# Altium schematic sheet Pack_monitoring.SchDoc(Pack_monitoring)
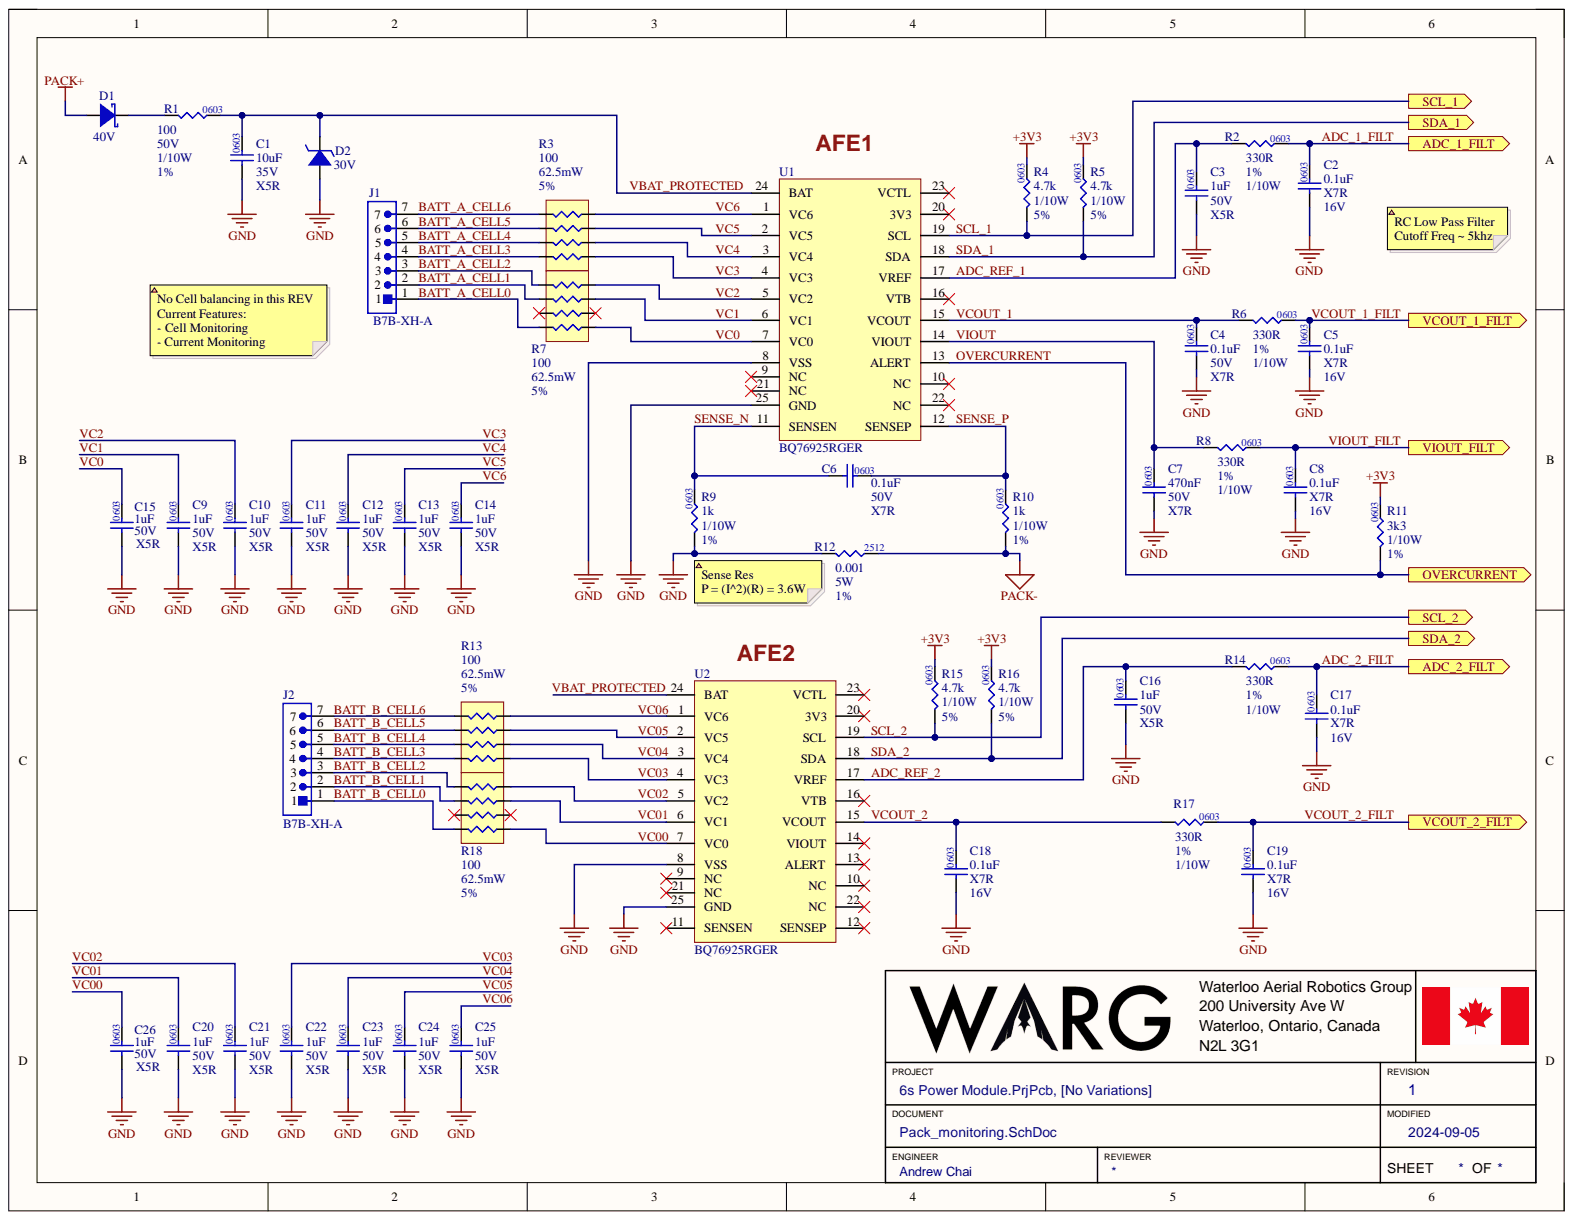
[diagram p0: Pack_monitoring.SchDoc]

## Parts
C1  value 10uF  Case/Package: 0603  Rated DC Voltage (URdc): 35V  Temperature Characteristics Code: X5R  [.CAP_0603-Footprint-1]  (hidden comment: GRM188R6YA106MA73J)
C2  value 0.1uF  Capactitance: 0.1uF  Package: 0603  Temperature Coefficient: X7R  Voltage: 16V  [.CAP_0603-Footprint-1]  (hidden comment: GCM188R71C104KA37D)
C3  value 1uF  Capacitance: 1uF  Case/Package: 0603  Dielectric: X5R  Rated DC Voltage (URdc): 50V  [.CAP_0603-Footprint-1]  (hidden comment: GRT188R61H105KE13D)
C4  Capacitance: 0.1uF  Case Code (Imperial): 0603  Dielectric: X7R  Voltage: 50V  [CAP0603]  (hidden comment: GCM188R71H104KA57D)
C5  value 0.1uF  Capactitance: 0.1uF  Package: 0603  Temperature Coefficient: X7R  Voltage: 16V  [.CAP_0603-Footprint-1]  (hidden comment: GCM188R71C104KA37D)
C6  Capacitance: 0.1uF  Case Code (Imperial): 0603  Dielectric: X7R  Voltage: 50V  [CAP0603]  (hidden comment: GCM188R71H104KA57D)
C7  Capacitance: 470nF  Case Code (Imperial): 0603  Dielectric: X7R  Voltage: 50V  [FP-0603-L_1_6_0_1-W_0_8_0-IPC_A]  (hidden comment: UMK107B7474KA-TR)
C8  value 0.1uF  Capactitance: 0.1uF  Package: 0603  Temperature Coefficient: X7R  Voltage: 16V  [.CAP_0603-Footprint-1]  (hidden comment: GCM188R71C104KA37D)
C9  value 1uF  Capacitance: 1uF  Case/Package: 0603  Dielectric: X5R  Rated DC Voltage (URdc): 50V  [.CAP_0603-Footprint-1]  (hidden comment: GRT188R61H105KE13D)
C10  value 1uF  Capacitance: 1uF  Case/Package: 0603  Dielectric: X5R  Rated DC Voltage (URdc): 50V  [.CAP_0603-Footprint-1]  (hidden comment: GRT188R61H105KE13D)
C11  value 1uF  Capacitance: 1uF  Case/Package: 0603  Dielectric: X5R  Rated DC Voltage (URdc): 50V  [.CAP_0603-Footprint-1]  (hidden comment: GRT188R61H105KE13D)
C12  value 1uF  Capacitance: 1uF  Case/Package: 0603  Dielectric: X5R  Rated DC Voltage (URdc): 50V  [.CAP_0603-Footprint-1]  (hidden comment: GRT188R61H105KE13D)
C13  value 1uF  Capacitance: 1uF  Case/Package: 0603  Dielectric: X5R  Rated DC Voltage (URdc): 50V  [.CAP_0603-Footprint-1]  (hidden comment: GRT188R61H105KE13D)
C14  value 1uF  Capacitance: 1uF  Case/Package: 0603  Dielectric: X5R  Rated DC Voltage (URdc): 50V  [.CAP_0603-Footprint-1]  (hidden comment: GRT188R61H105KE13D)
C15  value 1uF  Capacitance: 1uF  Case/Package: 0603  Dielectric: X5R  Rated DC Voltage (URdc): 50V  [.CAP_0603-Footprint-1]  (hidden comment: GRT188R61H105KE13D)
C16  value 1uF  Capacitance: 1uF  Case/Package: 0603  Dielectric: X5R  Rated DC Voltage (URdc): 50V  [.CAP_0603-Footprint-1]  (hidden comment: GRT188R61H105KE13D)
C17  value 0.1uF  Capactitance: 0.1uF  Package: 0603  Temperature Coefficient: X7R  Voltage: 16V  [.CAP_0603-Footprint-1]  (hidden comment: GCM188R71C104KA37D)
C18  value 0.1uF  Capactitance: 0.1uF  Package: 0603  Temperature Coefficient: X7R  Voltage: 16V  [.CAP_0603-Footprint-1]  (hidden comment: GCM188R71C104KA37D)
C19  value 0.1uF  Capactitance: 0.1uF  Package: 0603  Temperature Coefficient: X7R  Voltage: 16V  [.CAP_0603-Footprint-1]  (hidden comment: GCM188R71C104KA37D)
C20  value 1uF  Capacitance: 1uF  Case/Package: 0603  Dielectric: X5R  Rated DC Voltage (URdc): 50V  [.CAP_0603-Footprint-1]  (hidden comment: GRT188R61H105KE13D)
C21  value 1uF  Capacitance: 1uF  Case/Package: 0603  Dielectric: X5R  Rated DC Voltage (URdc): 50V  [.CAP_0603-Footprint-1]  (hidden comment: GRT188R61H105KE13D)
C22  value 1uF  Capacitance: 1uF  Case/Package: 0603  Dielectric: X5R  Rated DC Voltage (URdc): 50V  [.CAP_0603-Footprint-1]  (hidden comment: GRT188R61H105KE13D)
C23  value 1uF  Capacitance: 1uF  Case/Package: 0603  Dielectric: X5R  Rated DC Voltage (URdc): 50V  [.CAP_0603-Footprint-1]  (hidden comment: GRT188R61H105KE13D)
C24  value 1uF  Capacitance: 1uF  Case/Package: 0603  Dielectric: X5R  Rated DC Voltage (URdc): 50V  [.CAP_0603-Footprint-1]  (hidden comment: GRT188R61H105KE13D)
C25  value 1uF  Capacitance: 1uF  Case/Package: 0603  Dielectric: X5R  Rated DC Voltage (URdc): 50V  [.CAP_0603-Footprint-1]  (hidden comment: GRT188R61H105KE13D)
C26  value 1uF  Capacitance: 1uF  Case/Package: 0603  Dielectric: X5R  Rated DC Voltage (URdc): 50V  [.CAP_0603-Footprint-1]  (hidden comment: GRT188R61H105KE13D)
D1  Rep Pk Reverse Voltage-Max: 40V  [Copy of .SCHOTTKY-Footprint-1]  (hidden comment: MBR0540T1G)
D2  Reference Voltage-Nom: 30V  [BZT52C30-13-Footprint-1]  (hidden comment: BZT52C30-13)
J1  B7B-XH-A  [B7B-XH-A]
J2  B7B-XH-A  [B7B-XH-A]
R1  Case Code (Imperial): 0603  Voltage Rating: 50V  [.RES_0603-Footprint-1]  (hidden comment: RC0603FR-07100RL)
R2  value 330R  Case/Package: 0603  Tolerance: 1%  [.RES_0603-Footprint-1]  (hidden comment: AF0603FR-07330RL)
R3  value 100  Power: 62.5mW  Tolerance: 5%  [.RES_0603-Footprint-1]  (hidden comment: YC124JR-07100RL)
R4  value 4.7k  Case/Package: 0603  Power Rating: 1/10W  Resistance: 4.7k  Resistance Tolerance: 5%  [.RES_0603-Footprint-1]  (hidden comment: RC0603JR-074K7L)
R5  value 4.7k  Case/Package: 0603  Power Rating: 1/10W  Resistance: 4.7k  Resistance Tolerance: 5%  [.RES_0603-Footprint-1]  (hidden comment: RC0603JR-074K7L)
R6  value 330R  Case/Package: 0603  Tolerance: 1%  [.RES_0603-Footprint-1]  (hidden comment: AF0603FR-07330RL)
R7  value 100  [.RES_0603-Footprint-1]  (hidden comment: YC124JR-07100RL)
R8  value 330R  Case/Package: 0603  Tolerance: 1%  [.RES_0603-Footprint-1]  (hidden comment: AF0603FR-07330RL)
R9  value 1k  Case/Package: 0603  Power: 1/10W  Tolerance: 1%  [.RES_0603-Footprint-1]  (hidden comment: RC0603FR-071KL)
R10  value 1k  Case/Package: 0603  Power: 1/10W  Tolerance: 1%  [.RES_0603-Footprint-1]  (hidden comment: RC0603FR-071KL)
R11  value 3k3  Case/Package: 0603  Power: 1/10W  Tolerance: 1%  [.RES_0603-Footprint-1]  (hidden comment: RC0603FR-103K3L)
R12  value 0.001  Case/Package: 2512  Power: 5W  Resistance: 0.001  [.RES_0603-Footprint-1]  (hidden comment: CSS2H-2512R-1L00F)
R13  value 100  Power: 62.5mW  Tolerance: 5%  [.RES_0603-Footprint-1]  (hidden comment: YC124JR-07100RL)
R14  value 330R  Case/Package: 0603  Tolerance: 1%  [.RES_0603-Footprint-1]  (hidden comment: AF0603FR-07330RL)
R15  value 4.7k  Case/Package: 0603  Power Rating: 1/10W  Resistance: 4.7k  Resistance Tolerance: 5%  [.RES_0603-Footprint-1]  (hidden comment: RC0603JR-074K7L)
R16  value 4.7k  Case/Package: 0603  Power Rating: 1/10W  Resistance: 4.7k  Resistance Tolerance: 5%  [.RES_0603-Footprint-1]  (hidden comment: RC0603JR-074K7L)
R17  value 330R  Case/Package: 0603  Tolerance: 1%  [.RES_0603-Footprint-1]  (hidden comment: AF0603FR-07330RL)
R18  value 100  [.RES_0603-Footprint-1]  (hidden comment: YC124JR-07100RL)
U1  BQ76925RGER  [QFN50P400X400X100_HS-25N]
U2  BQ76925RGER  [QFN50P400X400X100_HS-25N]

## Ports
ADC_1_FILT, ADC_2_FILT, OVERCURRENT, SCL_1, SCL_2, SDA_1, SDA_2, VCOUT_1_FILT, VCOUT_2_FILT, VIOUT_FILT

## Nets
+3V3: R4.2 R5.2 R11.2 R15.2 R16.2
GND: C1.1 C2.1 C3.1 C4.1 C5.1 C7.1 C8.1 C9.1 C10.1 C11.1 C12.1 C13.1 C14.1 C15.1 C16.1 C17.1 C18.1 C19.1 C20.1 C21.1 C22.1 C23.1 C24.1 C25.1 C26.1 D2.1 R9.1 R12.1 U1.8(VSS) U1.25(GND) U2.8(VSS) U2.25(GND)
PACK+: D1.1
PACK-: R10.1 R12.2
ADC_1_FILT: C2.2 R2.2 ; port ADC_1_FILT
ADC_2_FILT: C17.2 R14.2 ; port ADC_2_FILT
ADC_REF_1: C3.2 R2.1 U1.17(VREF)
ADC_REF_2: C16.2 R14.1 U2.17(VREF)
BATT_A_CELL0: J1.1 R7.7
BATT_A_CELL1: J1.2 R7.3
BATT_A_CELL2: J1.3 R7.1
BATT_A_CELL3: J1.4 R3.7
BATT_A_CELL4: J1.5 R3.5
BATT_A_CELL5: J1.6 R3.3
BATT_A_CELL6: J1.7 R3.1
BATT_B_CELL0: J2.1 R18.7
BATT_B_CELL1: J2.2 R18.3
BATT_B_CELL2: J2.3 R18.1
BATT_B_CELL3: J2.4 R13.7
BATT_B_CELL4: J2.5 R13.5
BATT_B_CELL5: J2.6 R13.3
BATT_B_CELL6: J2.7 R13.1
OVERCURRENT: R11.1 U1.13(ALERT) ; port OVERCURRENT
SCL_1: R4.1 U1.19(SCL) ; port SCL_1
SCL_2: R15.1 U2.19(SCL) ; port SCL_2
SDA_1: R5.1 U1.18(SDA) ; port SDA_1
SDA_2: R16.1 U2.18(SDA) ; port SDA_2
SENSE_N: C6.1 R9.2 U1.11(SENSEN)
SENSE_P: C6.2 R10.2 U1.12(SENSEP)
VBAT_PROTECTED: C1.2 D2.2 R1.2 U1.24(BAT) U2.24(BAT)
VC0: C15.2 C26.2 R7.8 R18.8 U1.7(VC0) U2.7(VC0)  [also labelled VC00]
VC1: C9.2 C20.2 R7.4 R18.4 U1.6(VC1) U2.6(VC1)  [also labelled VC01]
VC2: C10.2 C21.2 R7.2 R18.2 U1.5(VC2) U2.5(VC2)  [also labelled VC02]
VC3: C11.2 C22.2 R3.8 R13.8 U1.4(VC3) U2.4(VC3)  [also labelled VC03]
VC4: C12.2 C23.2 R3.6 R13.6 U1.3(VC4) U2.3(VC4)  [also labelled VC04]
VC5: C13.2 C24.2 R3.4 R13.4 U1.2(VC5) U2.2(VC5)  [also labelled VC05]
VC6: C14.2 C25.2 R3.2 R13.2 U1.1(VC6) U2.1(VC6)  [also labelled VC06]
VCOUT_1: C4.2 R6.1 U1.15(VCOUT)
VCOUT_1_FILT: C5.2 R6.2 ; port VCOUT_1_FILT
VCOUT_2: C18.2 R17.1 U2.15(VCOUT)
VCOUT_2_FILT: C19.2 R17.2 ; port VCOUT_2_FILT
VIOUT: C7.2 R8.1 U1.14(VIOUT)
VIOUT_FILT: C8.2 R8.2 ; port VIOUT_FILT
NetD1_2: D1.2 R1.1

Not connected: R7 5 6; R18 5 6; U1 9(NC) 10(NC) 16(VTB) 20(3V3) 21(NC) 22(NC) 23(VCTL); U2 9(NC) 10(NC) 11(SENSEN) 12(SENSEP) 13(ALERT) 14(VIOUT) 16(VTB) 20(3V3) 21(NC) 22(NC) 23(VCTL)

## Library data of the parts
C1 = CMP-001-00087-1: Ceramic Capacitor, Multilayer, Ceramic, 35V, 20% +Tol, 20% -Tol, X5R, 15% TC, 10uF, Surface Mount, 0603; Multilayer: Yes; Capacitor Type: Ceramic; Component Kind: Standard; Dielectric Material: Ceramic; JESD-609 Code: e3; Max Operating Temperature: 85°C; Min Operating Temperature: -55°C; Mounting Technology: Surface Mount; Package Shape: Rectangular; Packaging: Tape and Reel; Pins: 2; Reach SVHC Compliant: Yes; RoHS Compliant: Yes; Terminal Finish: Nickel; Termination: Wraparound; Tolerance  (fill in as +-): 20%; Tolerance (fill in as +-): 20%; Library Name: WARG
C2 C5 C8 C17-C19 = CMP-001-00020-5: CAP CER 0.1uF 16V X7R 0603; Component Kind: Standard; Library Name: WARG
C3 C9-C16 C20-C26 = CMP-00096-3: CAP CER 1UF 50V X5R 0603; Capacitor Type: Ceramic; Tolerance: 10%; Component Kind: Standard; Mount: Surface Mount; Temperature Characteristics Code: X5R; Voltage Rating: 50V; Library Name: WARG
C4 C6 = CMP-00047-2: CAP CER 0.1uF 50V X7R 0603; Tolerance: 10%; Component Kind: Standard; Mount: Surface Mount; Library Name: WARG
C7 = CMP-00012-3: 0603 0.47 uF 50 V ±10% Tolerance X7R Multilayer Ceramic Capacitor; Case Code (Metric): 1608; Case/Package: 0603; Component Kind: Standard; Construction: Flat; Depth: 0.8mm; Halogen Free: Halogen Free; Height: 0.8mm; Lead Free: Lead Free; Length: 1.6mm; Max Operating Temperature: 125°C; Min Operating Temperature: -55°C; Mount: Surface Mount; Package Quantity: 1; Packaging: Tape and Reel; Radiation Hardening: No; RoHS Compliant: Yes; Series: M; Termination: SMD/SMT; Thickness: 0.035inch; Tolerance: 10%; Voltage Rating: 50V; Voltage Rating (DC): 50V; Width: 0.031inch; Library Name: WARG
D1 = CMP-004-00020-1: DIODE SCHOTTKY 40V 500MA SOD123; Additional Feature: FREE WHEELING DIODE; Diode Element Material: SILICON; Diode Type: RECTIFIER; Forward Voltage: 620mV; Non-rep Pk Forward Current-Max: 5.5A; Number of Elements: 1; Package Body Material: Plastic; Qualification Status: Not Qualified; Time @ Peak Reflow Temperature-Max (s): 40s; Component Kind: Standard; JESD-30 Code: R-PDSO-G2; JESD-609 Code: e3; Max Operating Temperature: 150°C; Max Output current: 500mA; Min Operating Temperature: -55°C; Moisture Sensitivity Level: 1; Package Shape: Rectangular; Package Style: SMALL OUTLINEMeter; Peak Reflow Temperature (Cel): 260°C; Pins: 2; Reach SVHC Compliant: Yes; RoHS Compliant: Yes; Surface Mount: Yes; Technology: SCHOTTKY; Terminal Finish: Tin; Terminal Form: Gull Wing; Terminal Position: DUAL; Library Name: WARG
D2 = CMP-004-00021-1: Zener Diode, 30V V(Z), 6.67%, 0.5W, Silicon, Unidirectional; Max Power Dissipation: 500mW; Number of Elements: 1; Package Body Material: Plastic; Qualification Status: Not Qualified; Test Current: 2mA; Time @ Peak Reflow Temperature-Max (s): 10s; Component Kind: Standard; Diode Element Material: SILICON; Diode Type: ZENER; JESD-30 Code: R-PDSO-G2; JESD-609 Code: e0; Max Operating Temperature: 150°C; Min Operating Temperature: -65°C; Package Shape: Rectangular; Package Style: SMALL OUTLINEMeter; Peak Reflow Temperature (Cel): 235°C; Pins: 2; Polarity: Unidirectional; RoHS Compliant: No; Surface Mount: Yes; Technology: ZENER; Terminal Finish: Tin; Terminal Form: Gull Wing; Terminal Position: DUAL; Voltage Tol-Max: 6.67%; Library Name: WARG
J1 J2 = CMP-002-00021-4: Header B7B-XH-A, 2.50mm, 7-contacts, top entry, THT /500/box; MF: JST Sales; Check_prices: https://www.snapeda.com/parts/S5B-XH-A/JST+Sales+America+Inc./view-part/?ref=eda; Component Kind: Standard; Component Type: Standard; Design Item ID: B7B-XH-A; MP: B7B-XH-A; Package: None; Pin Count: 7; Library Name: WARG
R1 = CMP-00022-3: RES 100 OHM 1% 1/10W 0603; Max Power Dissipation: 100mW; Resistance: 100; Temperature Coefficient: 100ppm/°C; Case Code (Metric): 1608; Case/Package: 0603; Component Kind: Standard; Construction: Rectangular; Depth: 0.8mm; Features: Moisture Resistant; Height: 0.45mm; Lead Free: Lead Free; Length: 1.6mm; Max Operating Temperature: 155°C; Military Standard: Not; Min Operating Temperature: -55°C; Number of Pins: 2; Number of Terminations: 2; Packaging: Tape and Reel; Power Rating: 1/10W; REACH SVHC: Unknown; Resistance Tolerance: 1%; Resistor Type: Thick Film; RoHS Compliant: Yes; Series: RC; Size Code: 0603; Technology: THICK FILM; Termination: SMD/SMT; Tolerance: 1%; Voltage Rating (DC): 50V; Width: 0.8mm; Library Name: WARG
R2 R6 R8 R14 R17 = CMP-009-00099-1: Fixed Resistor, Metal Glaze/thick Film, 0.1W, 330ohm, 75V, 1% +/-Tol, 100ppm/Cel, Surface Mount, 0603; Additional Feature: ANTI-SULFUR; Package Height: 0.45mm; Package Length: 1.6mm; Package Width: 0.8mm; Power: 1/10W; Rated Temperature: 70°C; Reference Standard: AEC-Q200; Resistance: 330; Temperature Coefficient: 100ppm/°C; Component Kind: Standard; Construction: Rectangular; Max Operating Temperature: 155°C; Min Operating Temperature: -55°C; Mounting Technology: Surface Mount; Package: 0603; Package Style: SMTMeter; Packaging: Tape and Reel; Pins: 2; Reach SVHC Compliant: Yes; Resistor Type: FIXED RESISTOR; RoHS Compliant: Yes; Termination: Wraparound; Working Voltage: 75V; Library Name: WARG
R3 R13 = CMP-009-00095-1: YC124 Series 4 Elements 100 Ohms 5 % Case 4 x 0402 SMD Chip Resistor Network; Component Kind: Standard; Package: 0804; Resistance: 100; Library Name: WARG
R4 R5 R15 R16 = CMP-009-00019-3: RES 4.7K OHM 5% 1/10W 0603; Voltage Rating (DC): 50V; Component Kind: Standard; Library Name: WARG
R7 R18 = CMP-009-00095-1: YC124 Series 4 Elements 100 Ohms 5 % Case 4 x 0402 SMD Chip Resistor Network; Power: 62.5mW; Tolerance: 5%; Component Kind: Standard; Package: 0804; Resistance: 100; Library Name: WARG
R9 R10 = CMP-009-00012-3: RES 1k OHM 1% 1/10W 0603; Component Kind: Standard; Library Name: WARG
R11 = CMP-00168-2: RES 3.3K OHM 1% 1/10W 0603; Rated Temperature: 70°C; Working Voltage: 75V; Component Kind: Standard; Library Name: WARG
R12 = CMP-009-00094-1: Fixed Resistor, Metal Strip, 5W, 0.001ohm, 1% +/-Tol, 50ppm/Cel, Surface Mount, 2512; Package Height: 0.42mm; Package Length: 6.35mm; Package Width: 3.05mm; Temperature Coefficient: 50ppm/°C; Component Kind: Standard; Construction: Rectangular; Max Operating Temperature: 170°C; Min Operating Temperature: -55°C; Package: 2512; Package Shape: Rectangular; Package Style: SMTMeter; Packaging: Tape and Reel; Pins: 2; Reach SVHC Compliant: Yes; Resistor Type: FIXED RESISTOR; RoHS Compliant: Yes; Technology: METAL ALLOY; Tolerance: 1%; Library Name: WARG
U1 U2 = CMP-00274-1: Power Supply Support Circuit, Adjustable, 1 Channel, PQCC24; Adjustable Threshold: Yes; Channels: 1; Max Supply Voltage: 26.4V; Min Supply Voltage: 4.2V; Nominal Supply Voltage: 21.6V; Package Body Material: Plastic; Package Equivalence Code: LCC24,.16SQ,20; Power Supplies: 4.2/26.4V; Qualification Status: Not Qualified; Supply Current-Max (Isup): 48uA; Case/Package: HVQCCN; Component Kind: Standard; Height - Seated (Max): 1mm; JESD-30 Code: R-PDSO-G20; JESD-609 Code: e4; Length: 4mm; Max Operating Temperature: 85°C; Min Operating Temperature: -25°C; Moisture Sensitivity Level: 2; Number of Channels: 1; Package Shape: Square; Package Style: CHIP CARRIER, HEAT SINK/SLUG, VERY THIN PROFILEMeter; Peak Reflow Temperature (Cel): 260°C; Pins: 24; Reach SVHC Compliant: Yes; RoHS Compliant: Yes; Surface Mount: Yes; Temperature Grade: OTHER; Terminal Finish: Nickel; Terminal Form: No Lead; Terminal Pitch: 0.5mm; Terminal Position: QUAD; Throw Configuration: POWER SUPPLY SUPPORT CIRCUIT; Width: 4mm; Library Name: WARG
